# Altium schematic sheet 08_nRF_RAM.SchDoc(08_nRF_RAM)
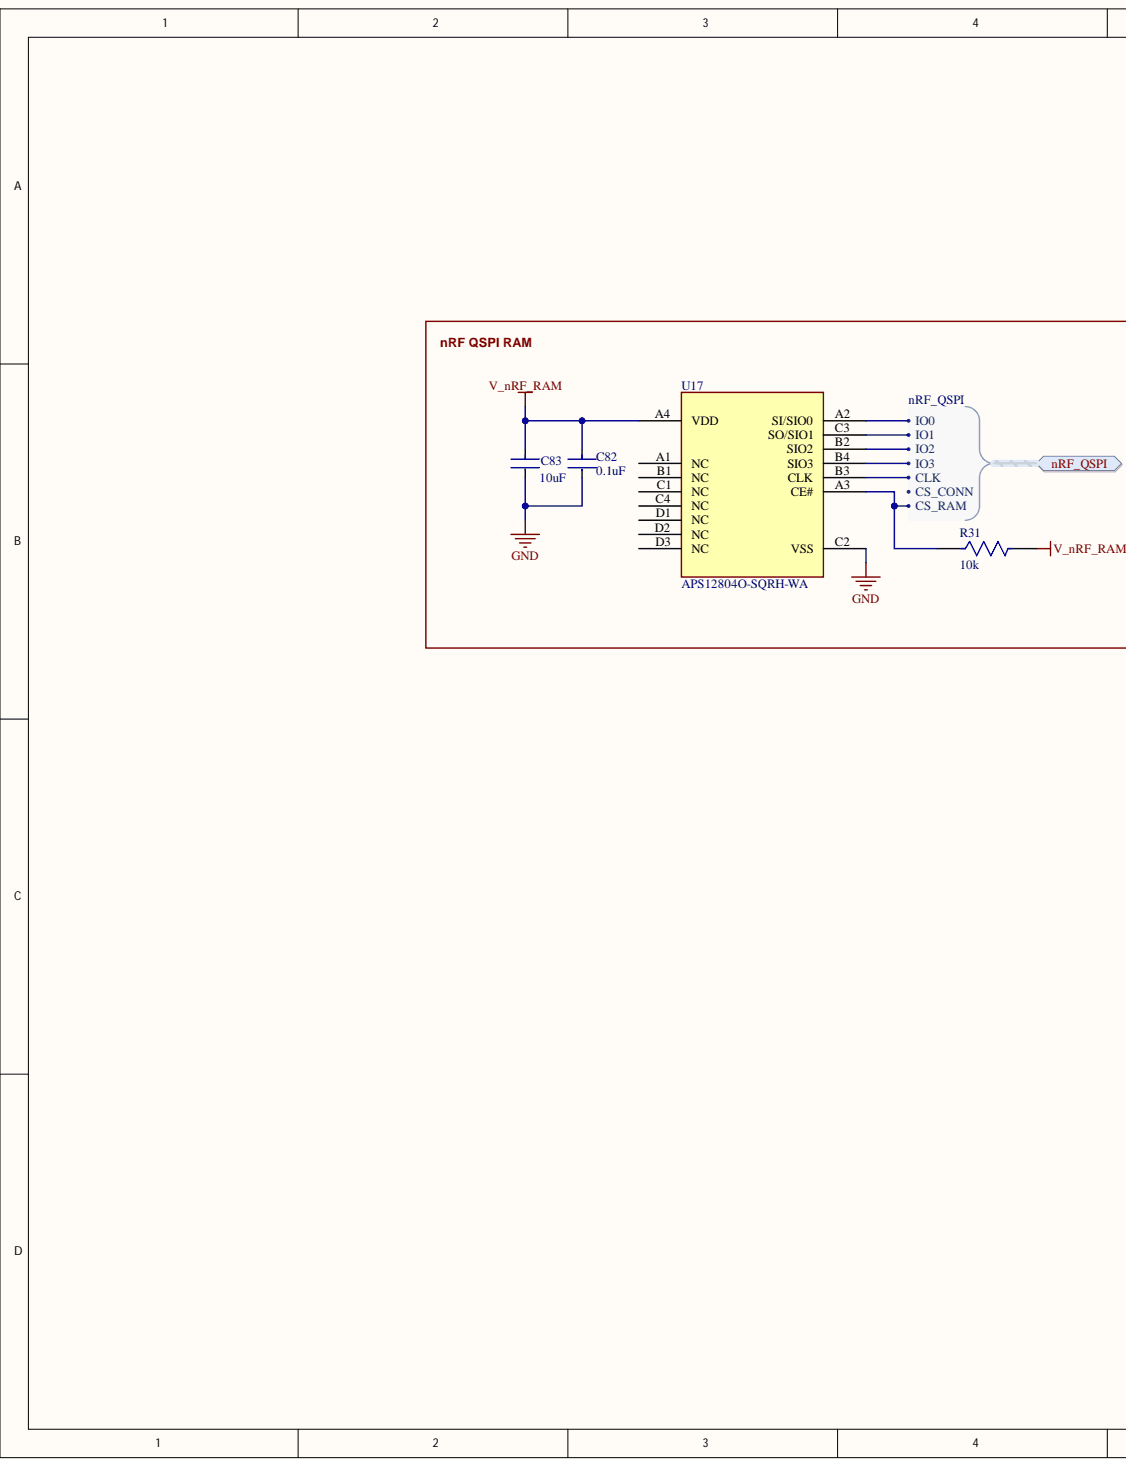
[diagram p0: 08_nRF_RAM.SchDoc, left]
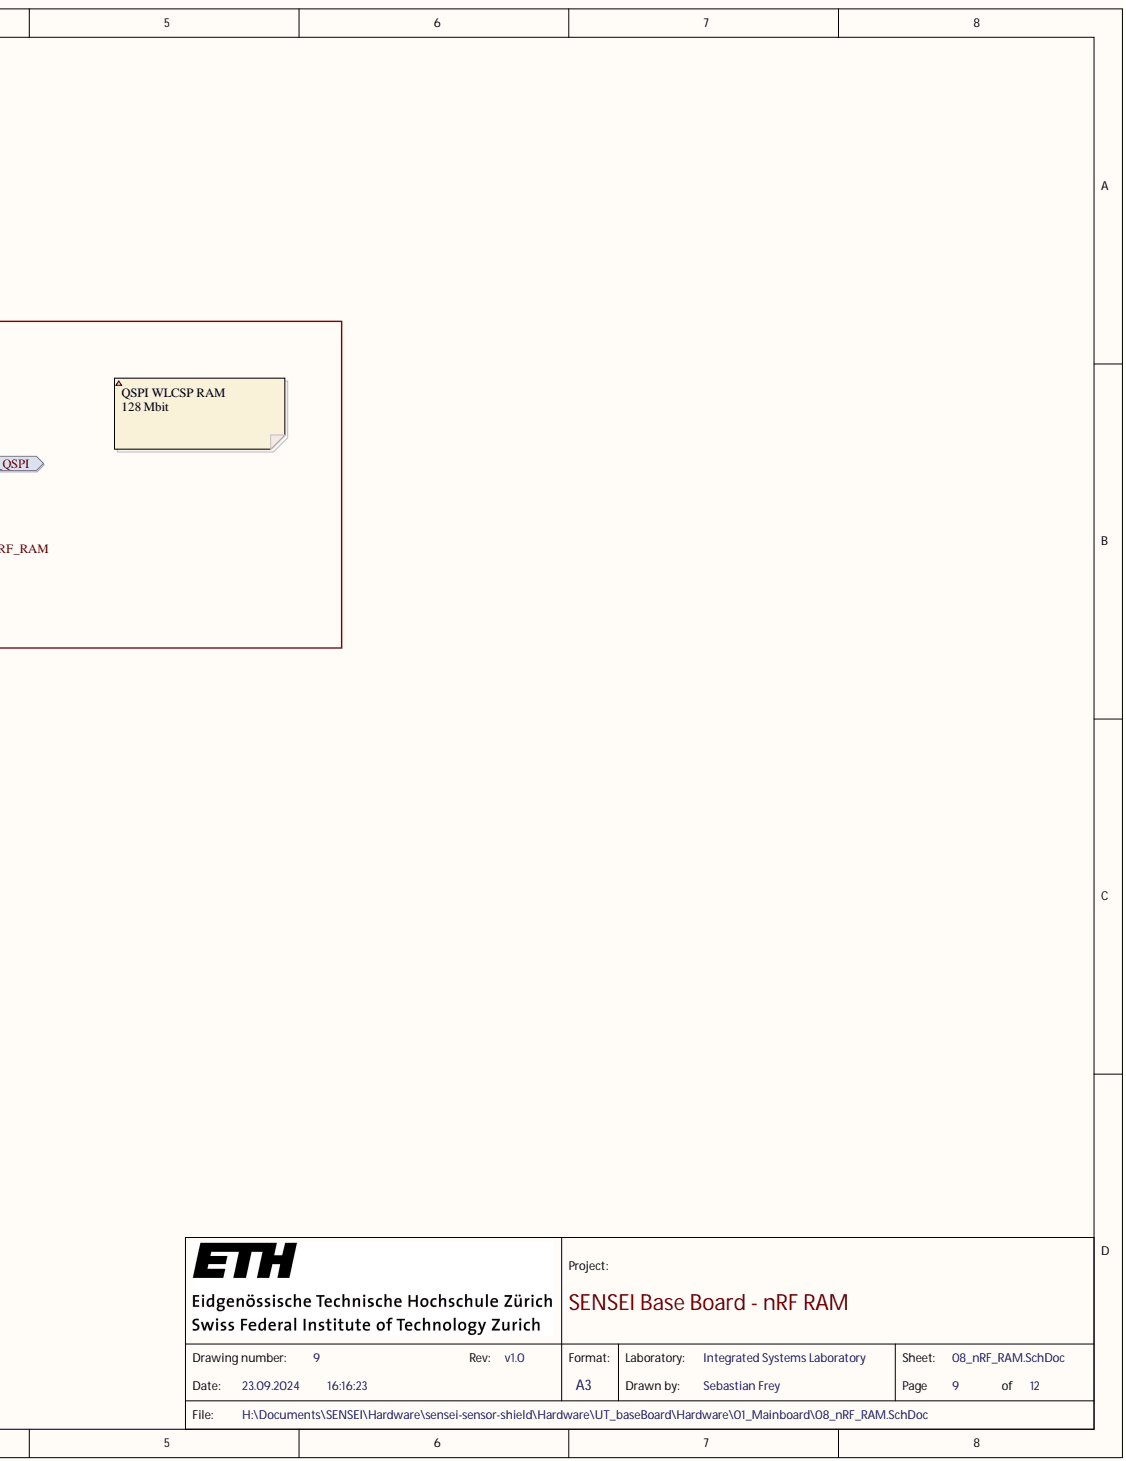
[diagram p1: 08_nRF_RAM.SchDoc, right]

## Parts
C82  Capacitance: 0.1uF  [FP-GRM033-0_03-IPC_B]  (hidden comment: GRM033Z71C104KE14D)
C83  value 10uF  Capacitance: 10uF  [FP-CL05A106MP5NUNC-IPC_B]  (hidden comment: CL05A106MP5NUNC)
R31  Resistance: 10k  [FP-RMCF0201-IPC_C]  (hidden comment: RMCF0201FT10K0)
U17  APS12804O-SQRH-WA  [APS12804O-SQRH-WA]

## Ports
nRF_QSPI, nRF_QSPI.CLK, nRF_QSPI.CS_CONN, nRF_QSPI.CS_RAM, nRF_QSPI.IO0, nRF_QSPI.IO1, nRF_QSPI.IO2, nRF_QSPI.IO3

## Nets
GND: C82.2 C83.2 U17.C2(VSS)
V_nRF_RAM: C82.1 C83.1 R31.2 U17.A4(VDD)
NetR31_1: R31.1 U17.A3(CE#) ; port nRF_QSPI
NetU17_A2: U17.A2(SI/SIO0) ; port nRF_QSPI
NetU17_B2: U17.B2(SIO2) ; port nRF_QSPI
NetU17_B3: U17.B3(CLK) ; port nRF_QSPI
NetU17_B4: U17.B4(SIO3) ; port nRF_QSPI
NetU17_C3: U17.C3(SO/SIO1) ; port nRF_QSPI
N00046: ; port nRF_QSPI

Not connected: U17 A1(NC) B1(NC) C1(NC) C4(NC) D1(NC) D2(NC) D3(NC)

## Library data of the parts
C82 = CMP-06035-035508-1: Chip Multilayer Ceramic Capacitors for General Purpose, 0201, 0.10uF, X7R, 15%, 10%, 16V; Case/Package: 0201; Component Kind: Standard; Library Name: Altium Content Vault
C83 = CMP-13271-000002-1: CAP CER 10UF 10V X5R 0402; Manufacturer: Samsung; Material: Ceramic; Temperature Coefficient: 15%; Tolerance: 20%; Automotive: No; Category: Cap; Component Kind: Standard; ComponentLink2Description: Datasheet; ComponentLink2URL: http://www.samsungsem.com/kr/support/product-search/mlcc/__icsFiles/afieldfile/2019/05/10/19-CL05A106MP5NUNC.pdf; Device Class L1: Passive Components; Device Class L2: Capacitors; Device Class L3: Ceramic Capacitors; Digikey Description: CAP CER 10UF 10V X5R 0402; Height: 0.7mm; Ipc Land Pattern Name: CAPC10050X50; Lead Free: Yes; Library Name: Altium Content Vault; Manufacturer Part Number: CL05A106MP5NUNC; Octopart: https://octopart.com/cl05a106mp5nunc-samsung-22457395; Package: CL05A106MP5NUNC; RoHS: TRUE; Temperature: -55°C to +85°C; Temperature Characteristic: X5R; Temperature Range High: +85°C; Temperature Range Low: -55°C; Voltage Rating: 10V
R31 = CMP-26527-002755-1: RES 10K OHM 1% 1/20W 0201; Max Power Dissipation: 50mW; Temperature Coefficient: 200ppm/°C; Case Code (Imperial): 0201; Case Code (Metric): 0603; Case/Package: 0201; Component Kind: Standard; Contact Plating: Tin; Height: 0.009inch; Length: 0.024inch; Library Name: Altium Content Vault; Max Operating Temperature: 125°C; Min Operating Temperature: -55°C; Mount: Surface Mount; Number of Terminations: 2; Packaging: Tape and Reel; Power Rating: 50mW; Resistor Type: Thick Film; RoHS Compliant: Yes; Series: RMCF; Tolerance: 1%; Width: 0.012inch
U17 = APS12804O-SQRH-WA: QSPI PSRAM in WLCSP package  144 MHz  128 Mbit; Mfr_Name: AP Memory; Component Kind: Standard; Library Name: EEG_SchLib.SchLib; Manufacturer_Part_Number: APS12804O-SQRH-WA
